# Altium schematic sheet bat_pak_reva.SchDoc
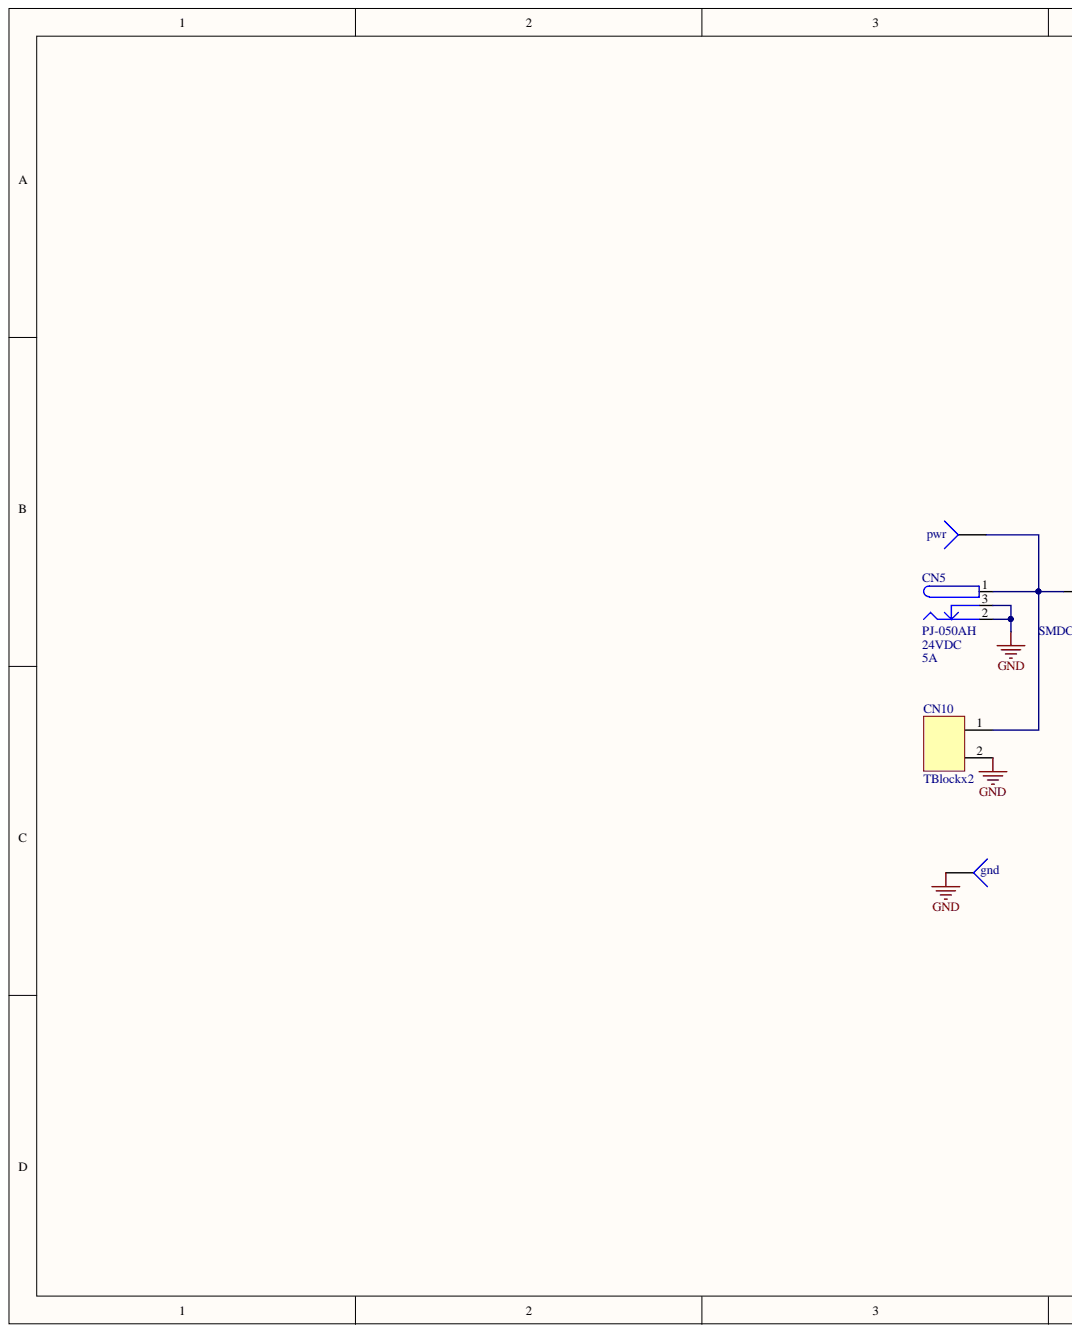
[diagram p0: bat_pak_reva.SchDoc, left]
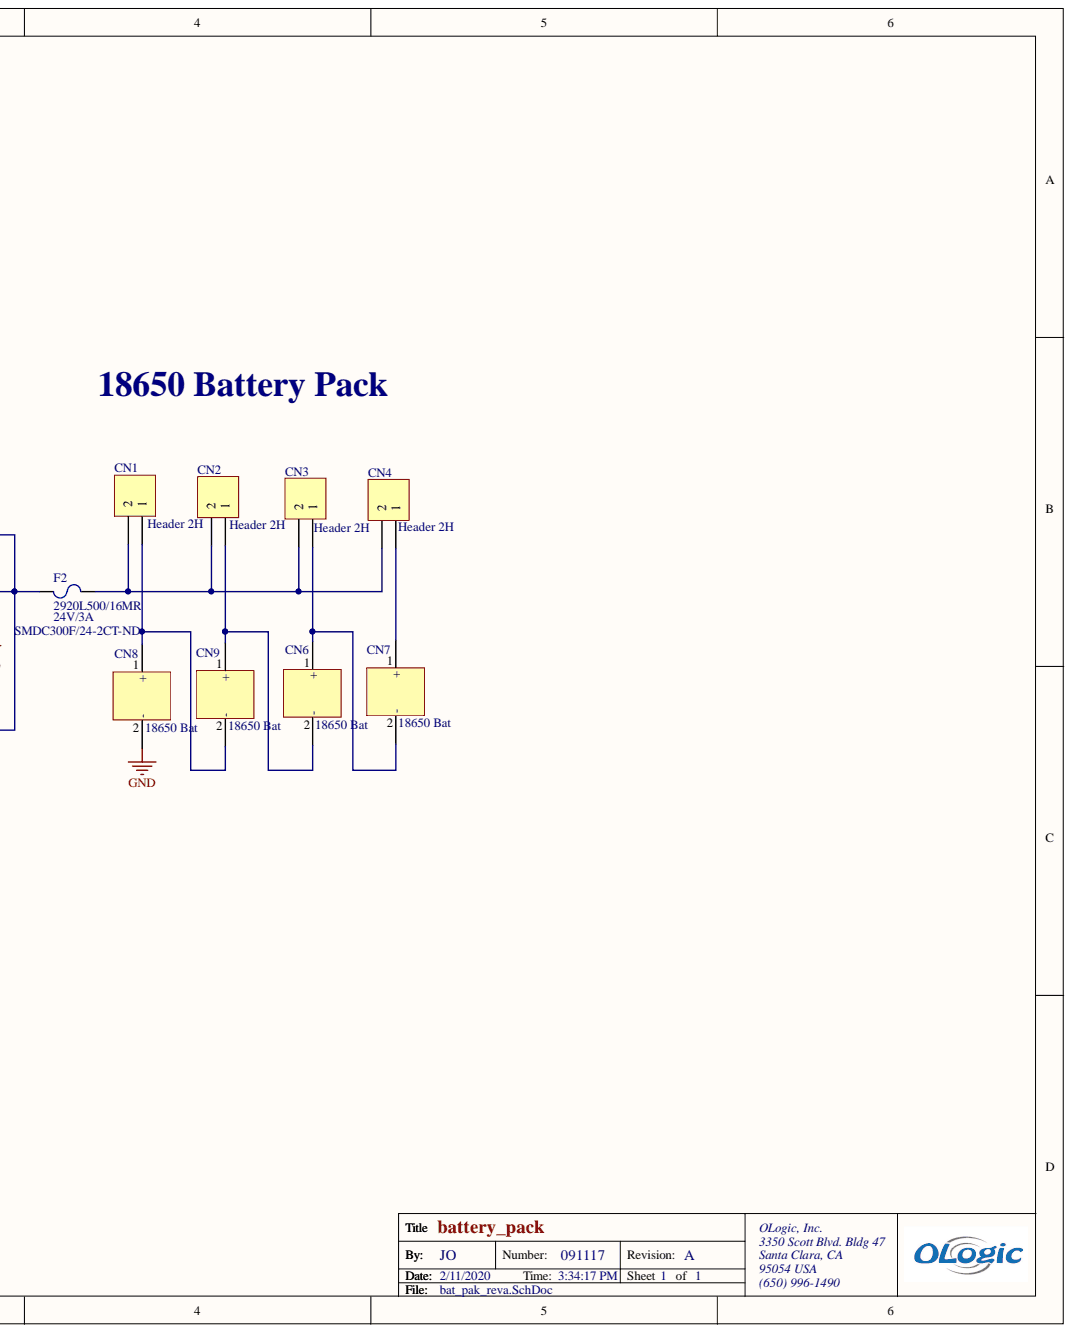
[diagram p1: bat_pak_reva.SchDoc, right]

## Parts
CN1  Header 2H  [HDR1X2H]
CN2  Header 2H  [HDR1X2H]
CN3  Header 2H  [HDR1X2H]
CN4  Header 2H  [HDR1X2H]
CN5  PJ-050AH  Current Rating: 5A  Voltage - Rated: 24VDC  [PJ-050AH]
CN6  18650 Bat  [battery_holder_18650]
CN7  18650 Bat  [battery_holder_18650]
CN8  18650 Bat  [battery_holder_18650]
CN9  18650 Bat  [battery_holder_18650]
CN10  TBlockx2  [TB-5MM-X2]
F2  2920L500/16MR  [2920 Fuse]
gnd  (no value)  [TestPoint-TH 35 mil]  (no BOM)
pwr  (no value)  [TestPoint-TH 35 mil]  (no BOM)

## Nets
GND: CN5.2 CN5.3 CN8.2 CN10.2 gnd.1
NetCN1_1: CN1.1 CN8.1 CN9.2
NetCN1_2: CN1.2 CN2.2 CN3.2 CN4.2 F2.2
NetCN2_1: CN2.1 CN6.2 CN9.1
NetCN3_1: CN3.1 CN6.1 CN7.2
NetCN4_1: CN4.1 CN7.1
NetCN5_1: CN5.1 CN10.1 F2.1 pwr.1

## Library data of the parts
CN1-CN4 = CMP-001-0287-01: Header, 2-Pin, Right Angle; Manufacturer: Harwin Inc.; Manufacturer Part Number: M20-9960245; Connector Type: Header, Cuttable; Contact Length - Mating: 0.240" (6.10mm); Contact Length - Post: 0.118" (3.00mm); Current Rating: 3A per Contact; Mated Stacking Heights: 11.72mm, 12.62mm, 12.72mm, 13.62mm, 18.22mm, 19.12mm; Material Flammability Rating: UL94 V-0; Number of Positions: 2; Number of Positions Loaded: All; Pitch - Mating: 0.100" (2.54mm); Shrouding: Unshrouded; Style: Board to Board or Cable; Applications: -; Component Kind: Standard; ComponentLink1Description: https://cdn.harwin.com/pdfs/M20-996.pdf; ComponentLink1URL: https://cdn.harwin.com/pdfs/M20-996.pdf; Component Name: Header 2H; Contact Finish - Mating: Gold; Contact Finish - Post: -; Contact Finish Thickness - Mating: -; Contact Finish Thickness - Post: -; Contact Material: Brass; Contact Shape: Square; Contact Type: Male Pin; Designator: Header 2H; Fastening Type: -; Features: -; Ingress Protection: -; Insulation Color: Black; Insulation Height: 0.100" (2.54mm); Insulation Material: Polyamide (PA6T), Nylon 6T; LatestRevisionDate: 17-Jul-2002; LatestRevisionNote: Re-released for DXP Platform.; Mounting Type: Through Hole, Right Angle; Number of Rows: 1; Operating Temperature: -40°C ~ 105°C; Overall Contact Length: -; Packaging: Bulk; Part Status: Active; Pin Count: 2; Pricing 1: 1=0.15, 10=0.141, 100=0.087, 500=0.072, 1000=0.063, 5000=0.054, 10000=0.0525 (USD); RoHS: RoHS Compliant; Row Spacing - Mating: -; Signal Integrity: Connector; Stock 1: 17504; Termination: Solder; Voltage Rating: -; Library Name: OLogic Nexus Vault; Publisher: Altium Limited
CN5 = CMP-001-0507-01: Power Barrel Connector Jack 2.00mm ID 5.50mm OD Through Hole, Right Angle; Manufacturer: CUI; Gender: Male; Internal Switch(s): Single Switch, Normally Closed; Number of Positions/Contacts: 2 Conductors, 3 Contacts; Plug/Mating Plug Diameter: 2.1mm ID, 5.5mm OD; Shielding: Unshielded; Color: Black; Component Kind: Standard; ComponentLink1Description: http://www.mouser.com/ds/2/670/PJ-050AH-524150.pdf; ComponentLink1URL: http://www.mouser.com/ds/2/670/PJ-050AH-524150.pdf; Component Name: PJ-050AH; Connector Type: Jack; Contact Material: Copper; Contact Material - Plating: Nickel; Designator: PJ-050AH; Family: Barrel - Power Connectors; Features: -; Housing Color: Black; Housing Material: Thermoplastic; Manufacturer Part Number: PJ-050AH; Mounting Type: Through Hole, Right Angle; Operating Temperature: -25°C ~ 85°C; Pin Count: 3; Pricing 1: 1=0.78, 10=0.689, 25=0.648, 50=0.621, 100=0.594, 250=0.54, 500=0.486, 1000=0.432, 2500=0.3915 (USD); Series: -; Stock 1: 4408; Termination: Solder; Library Name: OLogic Nexus Vault
CN10 = CMP-001-0545-01: 5mm Terminal Block x 2; Manufacturer: OST; MPN: OSTTC020160; PN: 164794; Vendor: Jameco; Component Kind: Standard; Component Name: TBlockx2; Designator: TBlockx2; Pin Count: 2; Library Name: OLogic Nexus Vault
F2 = CMP-001-0852-01: PTC RESET FUSE 16V 5A 2920; Max Current Rating: 40A; Max Power Dissipation: 2W; Time to Trip: 5s; Trip Current: 10A; Case/Package: 2920; Case Code (Imperial): 2920; Case Code (Metric): 7351; Component Kind: Standard; Height: 1.6mm; Hold Current: 5A; Lead Free: Lead Free; Length: 7.98mm; Max Operating Temperature: 85°C; Min Operating Temperature: -40°C; Package Quantity: 1; Packaging: Tape and Reel; REACH SVHC: No SVHC; Termination: SMD/SMT; Voltage Rating (DC): 16V; Width: 5.44mm; Library Name: OLogic Nexus Vault
gnd pwr = TestPoint: Test Point; Library Name: OLogic-Misc.IntLib
CN6-CN9 = (no symbol name): Component Kind: Standard
